# Altium schematic sheet Sheet1.SchDoc(Sheet1)
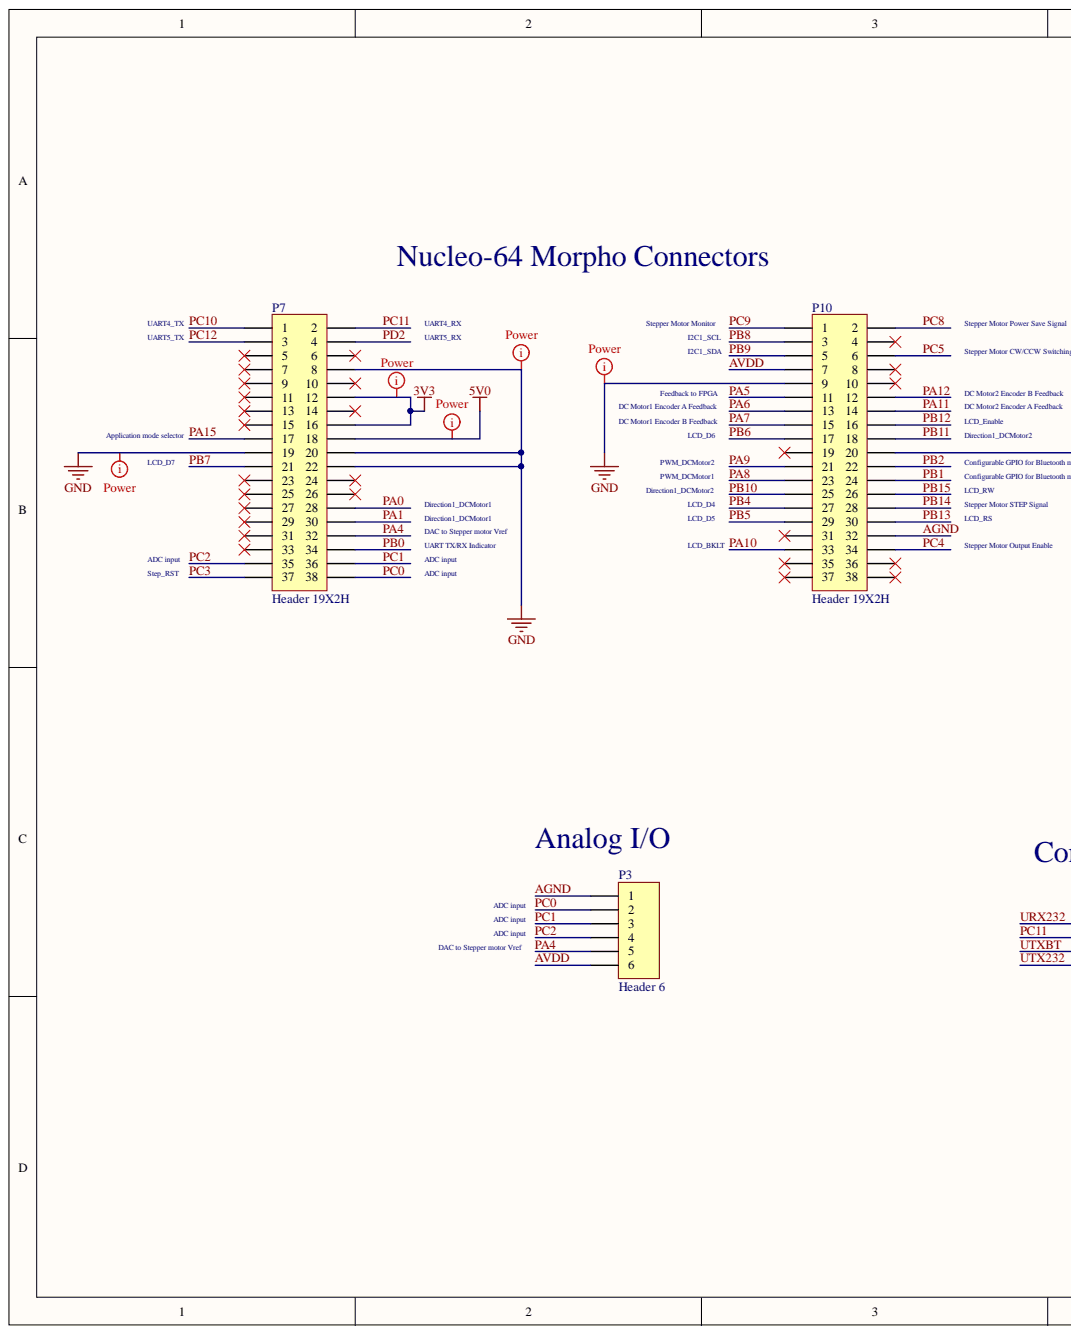
[diagram p0: Sheet1.SchDoc, left]
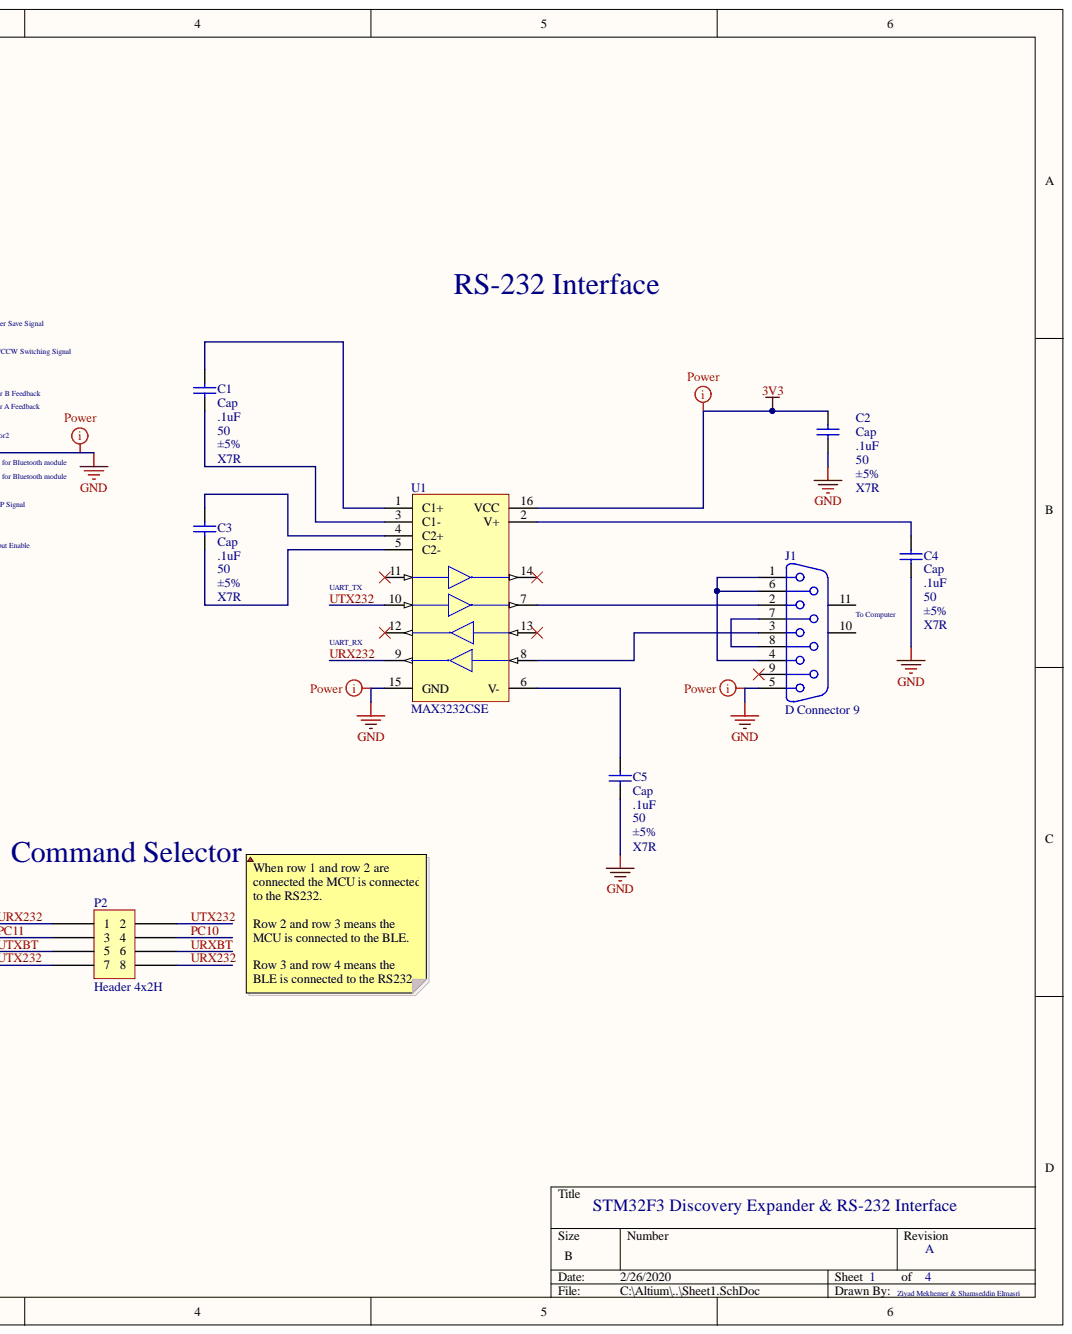
[diagram p1: Sheet1.SchDoc, right]

## Parts
C1  Cap  value .1uF  Tolerance: ±5%  [CAPC2012X09M]
C2  Cap  value .1uF  Type: X7R  Tolerance: ±5%  [CAPC2012X09M]
C3  Cap  value .1uF  Tolerance: ±5%  [CAPC2012X09M]
C4  Cap  value .1uF  Tolerance: ±5%  [CAPC2012X09M]
C5  Cap  value .1uF  Tolerance: ±5%  [CAPC2012X09M]
J1  D Connector 9  [DSUB1.385-2H9]
P2  Header 4x2H  [Header 4x2H]
P3  Header 6  [HDR1X6]
P7  Header 19X2H  [PPPC192LFBN-RC]
P10  Header 19X2H  [PPPC192LFBN-RC]
U1  MAX3232CSE  [NSO16_N]

## Nets
3V3: C2.2 P7.12 P7.16 U1.16(VCC)
5V0: P7.18
GND: C2.1 C4.2 C5.1 J1.5 P7.8 P7.19 P7.20 P7.22 P10.9 P10.20 U1.15(GND)
AGND: P3.1 P10.32
AVDD: P3.6 P10.7
PA0: P7.28
PA1: P7.30
PA4: P3.5 P7.32
PA5: P10.11
PA6: P10.13
PA7: P10.15
PA8: P10.23
PA9: P10.21
PA10: P10.33
PA11: P10.14
PA12: P10.12
PA15: P7.17
PB0: P7.34
PB1: P10.24
PB2: P10.22
PB4: P10.27
PB5: P10.29
PB6: P10.17
PB7: P7.21
PB8: P10.3
PB9: P10.5
PB10: P10.25
PB11: P10.18
PB12: P10.16
PB13: P10.30
PB14: P10.28
PB15: P10.26
PC0: P3.2 P7.38
PC1: P3.3 P7.36
PC2: P3.4 P7.35
PC3: P7.37
PC4: P10.34
PC5: P10.6
PC8: P10.2
PC9: P10.1
PC10: P2.4 P7.1
PC11: P2.3 P7.2
PC12: P7.3
PD2: P7.4
URX232: P2.1 P2.8 U1.9
URXBT: P2.6
UTX232: P2.2 P2.7 U1.10
UTXBT: P2.5
NetC1_1: C1.1 U1.3(C1-)
NetC1_2: C1.2 U1.1(C1+)
NetC3_1: C3.1 U1.5(C2-)
NetC3_2: C3.2 U1.4(C2+)
NetC4_1: C4.1 U1.2(V+)
NetC5_2: C5.2 U1.6(V-)
NetJ1_1: J1.1 J1.4 J1.6
NetJ1_2: J1.2 U1.7
NetJ1_3: J1.3 U1.8
NetJ1_7: J1.7 J1.8

Not connected: J1 9 10 11; P7 5 6 7 9 10 11 13 14 15 23 24 25 26 27 29 31 33; P10 4 8 10 19 31 35 36 37 38; U1 11 12 13 14

## Library data of the parts
C1-C5 = CAP-X7R-.1uF-50V-±5%: CAP CER .1uF 50v X7R 0805; MPN: C0805C104J5RACTU; WV: 50; PN: 399-1171-1-ND; URL: http://www.digikey.ca/product-detail/en/C0805C104J5RACTU/399-1171-1-ND; Name: CAP-X7R-.1uF-50V-±5%; Library Name: esd_library.IntLib; Component Kind: Standard
  C1 C3-C5: Type: X7R
J1 = D Connector 9: Receptacle Assembly, 9 Position, Right Angle; MPN: ADF09A-KG-TASB3-R; PN: AE10921-ND; URL: http://www.digikey.ca/product-detail/en/ADF09A-KG-TASB3-R/AE10921-ND; Signal Integrity: Connector; Library Name: esd_library.IntLib; Component Kind: Standard
P2 = Header 4x2H: 4x2 Male Header; Library Name: BT_Components.SchLib; ComponentLink2URL: https://s3.amazonaws.com/catalogspreads-pdf/PAGE112-113%20.100%20MALE%20HDR.pdf; ComponentLink2Description: Datasheet; ComponentLink1URL: https://www.digikey.ca/product-detail/en/sullins-connector-solutions/PEC04DFAN/S2212E-04-ND/860881; ComponentLink1Description: Digikey; Component Kind: Standard
P3 = Header 6: Header, 6-Pin Male; MPN: PEC06SFAN; PN: S1212E-06-ND; URL: http://www.digikey.ca/product-detail/en/PEC06SFAN/S1212E-06-ND; Library Name: esd_library.IntLib; Component Kind: Standard
P7 P10 = Header 19X2H: 38 Position Header Connector 0.100in (2.54mm) Through Hole Gold; PN: S7122-ND; URL: https://www.digikey.ca/product-detail/en/sullins-connector-solutions/PPPC192LFBN-RC/S7122-ND; Signal Integrity: Connector; MPN: PPPC192LFBN-RC; Library Name: esd_library.IntLib; Component Kind: Standard
U1 = MAX3232CSE: 3.0V TO 5.5V, Low-Power, up to 1Mbps, True RS-232 Transceiver Using Four 0.1µF External Capacitor; MPN: MAX3232CSE+; PN: MAX3232CSE+-ND; URL: http://www.digikey.ca/product-detail/en/MAX3232CSE%2B/MAX3232CSE%2B-ND; Published: 16-Jan-2001; PCB3D: NSO16; PackageReference: NSO16; PackageDescription: 16-Pin Small Outline Integrated Circuit 1.27 mm Pitch; Library Name: esd_library.IntLib; LatestRevisionNote: IPC-7351 Footprint Added.; LatestRevisionDate: 13-Apr-2006; DatasheetVersion: Mar-1999; ComponentLink2URL: http://pdfserv.maxim-ic.com/en/ds/MAX3222-MAX3241.pdf; ComponentLink2Description: Datasheet; ComponentLink1URL: http://www.maxim-ic.com/; ComponentLink1Description: Manufacturer Link; Component Kind: Standard; Code_JEDEC: MS-012-AC; Code_IPC: SOIC127P600-16; Publisher: Altium Limited
